# Altium schematic sheet 09_PMU.SchDoc(09_PMU)
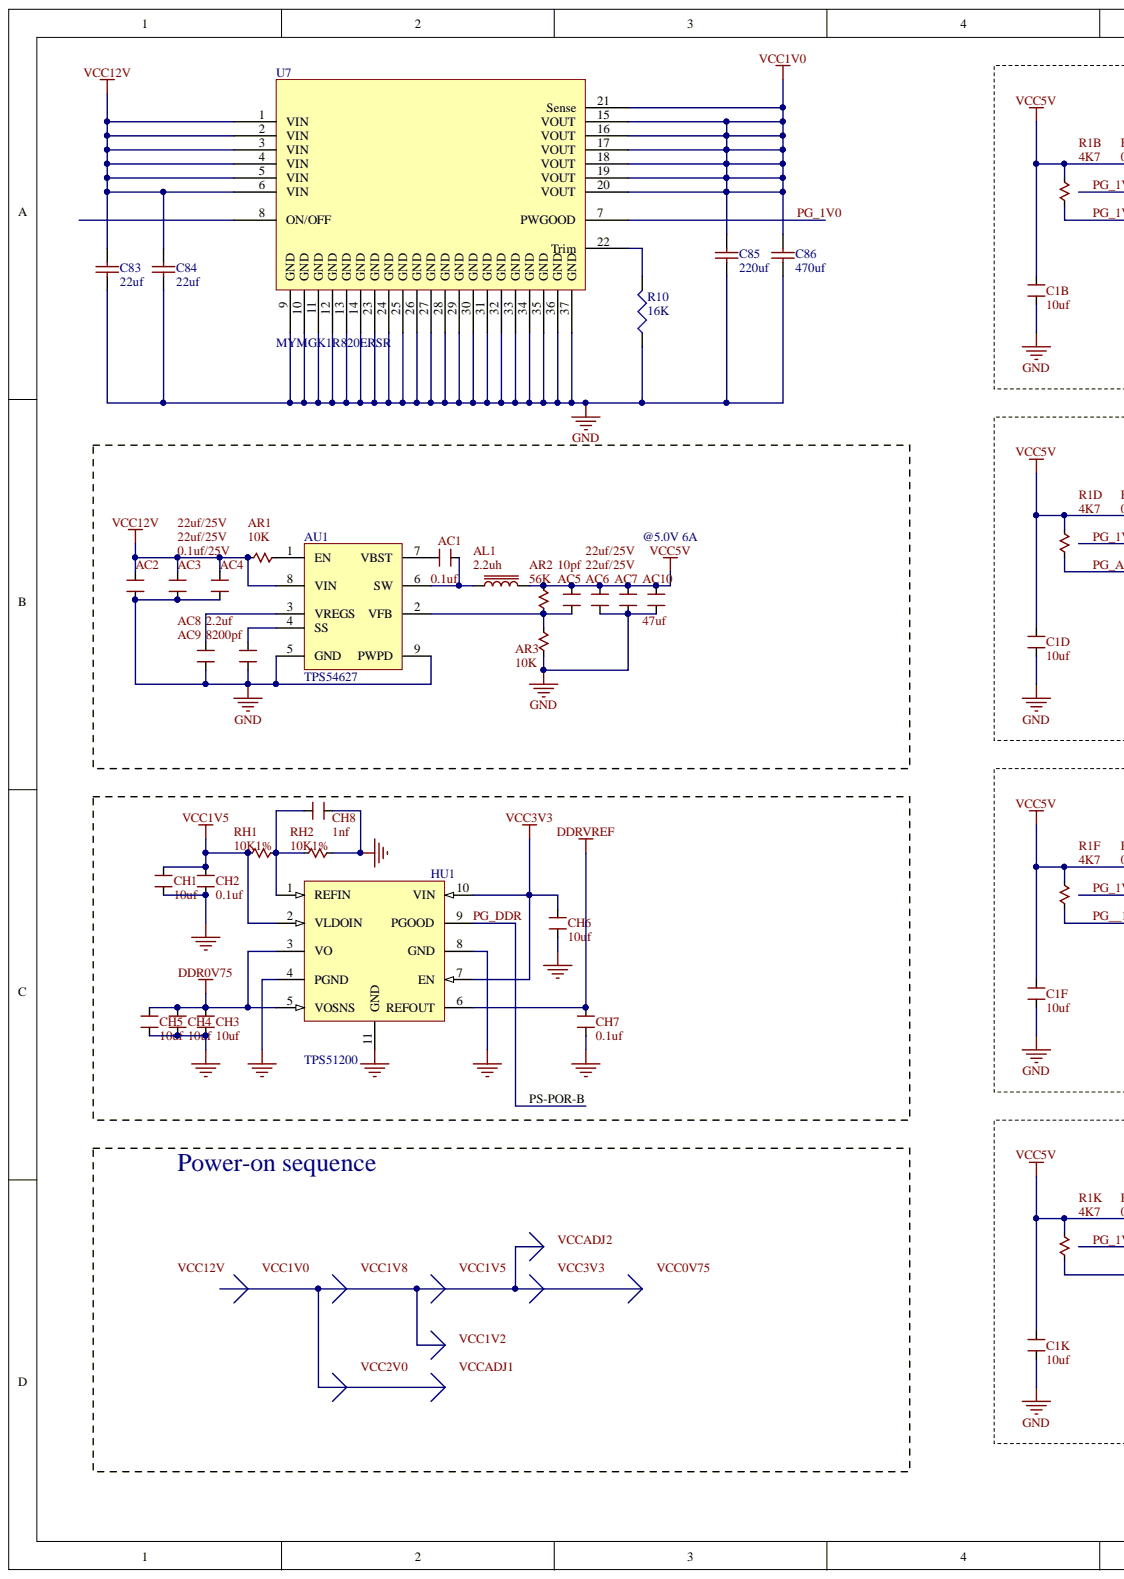
[diagram p0: 09_PMU.SchDoc, left]
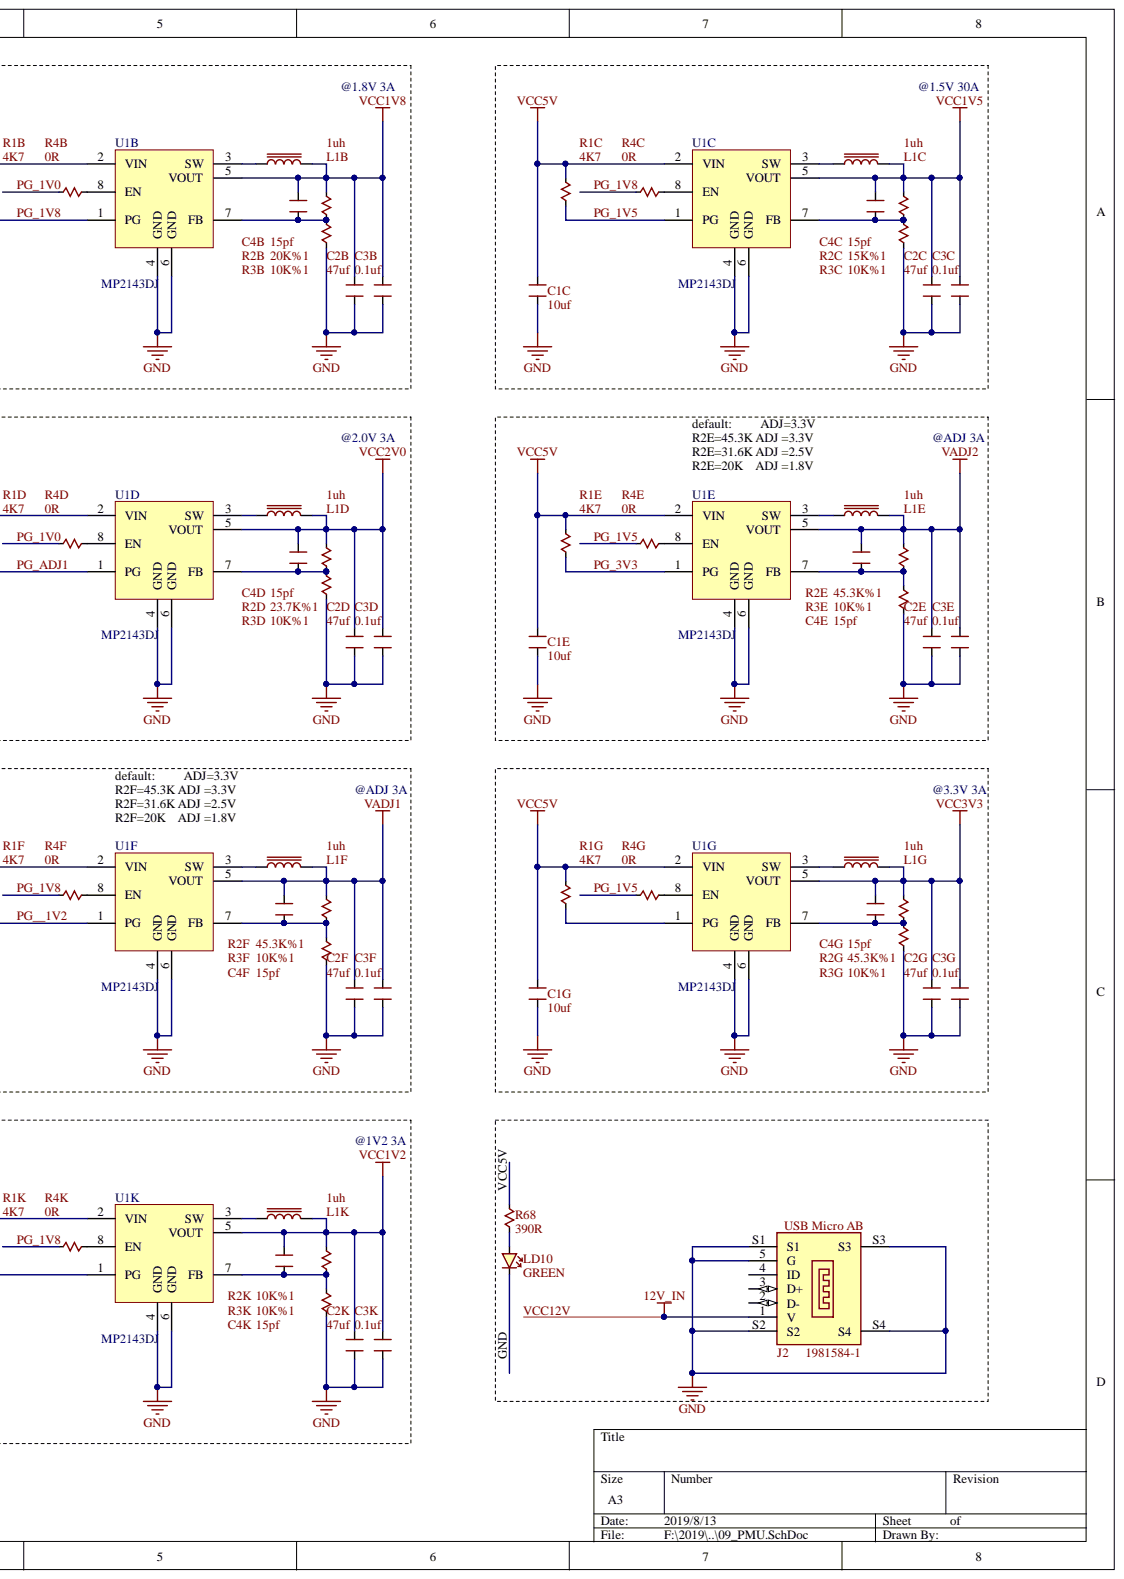
[diagram p1: 09_PMU.SchDoc, right]

## Parts
AC1  0.1uf  [CAP0402]
AC2  22uf/25V  [CAP0603]
AC3  22uf/25V  [CAP0603]
AC4  0.1uf/25V  [CAP0603]
AC5  10pf  [CAP0402]
AC6  22uf/25V  [CAP0603]
AC7  22uf/25V  [CAP0603]
AC8  2.2uf  [CAP0402]
AC9  8200pf  [CAP0402]
AC10  47uf  [C1206]
AL1  2.2uh  [L4X4X2]
AR1  10K  [RES0402]
AR2  56K  [RES0402]
AR3  10K  [RES0402]
AU1  TPS54627  [TSOP8]
C1B  10uf  [CAP0402]
C1C  10uf  [CAP0402]
C1D  10uf  [CAP0402]
C1E  10uf  [CAP0402]
C1F  10uf  [CAP0402]
C1G  10uf  [CAP0402]
C1K  10uf  [CAP0402]
C2B  47uf  [CAP0603]
C2C  47uf  [CAP0603]
C2D  47uf  [CAP0603]
C2E  47uf  [CAP0603]
C2F  47uf  [CAP0603]
C2G  47uf  [CAP0603]
C2K  47uf  [CAP0603]
C3B  0.1uf  [CAP0402]
C3C  0.1uf  [CAP0402]
C3D  0.1uf  [CAP0402]
C3E  0.1uf  [CAP0402]
C3F  0.1uf  [CAP0402]
C3G  0.1uf  [CAP0402]
C3K  0.1uf  [CAP0402]
C4B  15pf  [CAP0402]
C4C  15pf  [CAP0402]
C4D  15pf  [CAP0402]
C4E  15pf  [CAP0402]
C4F  15pf  [CAP0402]
C4G  15pf  [CAP0402]
C4K  15pf  [CAP0402]
C83  22uf  [c1206]
C84  22uf  [c1206]
C85  220uf  [C1206]
C86  470uf  [CAP-D]
CH1  10uf  [CAP0402]
CH2  0.1uf  [CAP0402]
CH3  10uf  [CAP0402]
CH4  10uf  [CAP0402]
CH5  10uf  [CAP0402]
CH6  10uf  [CAP0402]
CH7  0.1uf  [CAP0402]
CH8  1nf  [CAP0402]
HU1  TPS51200  [VQFN10]
J2  1981584-1  [MicroUsb]
L1B  1uh  [IHLP-2020BZ-01]
L1C  1uh  [IHLP-2020BZ-01]
L1D  1uh  [IHLP-2020BZ-01]
L1E  1uh  [IHLP-2020BZ-01]
L1F  1uh  [IHLP-2020BZ-01]
L1G  1uh  [IHLP-2020BZ-01]
L1K  1uh  [IHLP-2020BZ-01]
LD10  value GREEN  [D0603]  (hidden comment: LED)
R1B  4K7  [RES0402]
R1C  4K7  [RES0402]
R1D  4K7  [RES0402]
R1E  4K7  [RES0402]
R1F  4K7  [RES0402]
R1G  4K7  [RES0402]
R1K  4K7  [RES0402]
R2B  20K%1  [RES0402]
R2C  15K%1  [RES0402]
R2D  23.7K%1  [RES0402]
R2E  45.3K%1  [RES0402]
R2F  45.3K%1  [res0402]
R2G  45.3K%1  [RES0402]
R2K  10K%1  [RES0402]
R3B  10K%1  [RES0402]
R3C  10K%1  [RES0402]
R3D  10K%1  [RES0402]
R3E  10K%1  [RES0402]
R3F  10K%1  [RES0402]
R3G  10K%1  [RES0402]
R3K  10K%1  [RES0402]
R4B  0R  [RES0402]
R4C  0R  [RES0402]
R4D  0R  [RES0402]
R4E  0R  [RES0402]
R4F  0R  [RES0402]
R4G  0R  [RES0402]
R4K  0R  [RES0402]
R10  16K  [J1-0603]
R68  390R  [RES0402]
RH1  10K1%  [RES0402]
RH2  10K1%  [RES0402]
U1B  MP2143DJ  [SOT23-8]
U1C  MP2143DJ  [SOT23-8]
U1D  MP2143DJ  [SOT23-8]
U1E  MP2143DJ  [SOT23-8]
U1F  MP2143DJ  [SOT23-8]
U1G  MP2143DJ  [SOT23-8]
U1K  MP2143DJ  [SOT23-8]
U7  MYMGK1R820ERSR  [MYMGK1R820ERSR]

## Nets
DDR0V75: CH3.2 CH4.2 CH5.2 HU1.3(VO) HU1.5(VOSNS)
DDRVREF: CH7.2 HU1.6(REFOUT)
VADJ1: C2F.1 C3F.1 C4F.1 L1F.2 R2F.1 U1F.5(VOUT)
VADJ2: C2E.1 C3E.1 C4E.1 L1E.2 R2E.1 U1E.5(VOUT)
GND: AC2.1 AC3.1 AC4.1 AC6.1 AC7.1 AC8.1 AC9.1 AC10.1 AR3.2 AU1.5(GND) AU1.9(PWPD) C1B.2 C1C.2 C1D.2 C1E.2 C1F.2 C1G.2 C1K.2 C2B.2 C2C.2 C2D.2 C2E.2 C2F.2 C2G.2 C2K.2 C3B.2 C3C.2 C3D.2 C3E.2 C3F.2 C3G.2 C3K.2 C83.1 C84.1 C85.1 C86.1 CH1.1 CH2.1 CH3.1 CH4.1 CH5.1 CH6.1 CH7.1 CH8.1 HU1.4(PGND) HU1.8(GND) HU1.11(GND) J2.5(G) J2.S1 J2.S2 J2.S3 J2.S4 LD10.K R3B.2 R3C.2 R3D.2 R3E.2 R3F.2 R3G.2 R3K.2 R10.1 RH2.2 U1B.4(GND) U1B.6(GND) U1C.4(GND) U1C.6(GND) U1D.4(GND) U1D.6(GND) U1E.4(GND) U1E.6(GND) U1F.4(GND) U1F.6(GND) U1G.4(GND) U1G.6(GND) U1K.4(GND) U1K.6(GND) U7.9(GND) U7.10(GND) U7.11(GND) U7.12(GND) U7.13(GND) U7.14(GND) U7.23(GND) U7.24(GND) U7.25(GND) U7.26(GND) U7.27(GND) U7.28(GND) U7.29(GND) U7.30(GND) U7.31(GND) U7.32(GND) U7.33(GND) U7.34(GND) U7.35(GND) U7.36(GND) U7.37(GND)
PG_1V0: R4B.1 R4D.1 U7.7(PWGOOD)
PG_1V5: R1C.2 R4E.1 R4G.1 U1C.1(PG)
PG_1V8: R1B.2 R4C.1 R4F.1 R4K.1 U1B.1(PG)
PG_3V3: R1E.2 U1E.1(PG)
PG__1V2: R1F.2 U1F.1(PG)
PG_ADJ1: R1D.2 U1D.1(PG)
PG_DDR: HU1.9(PGOOD)
VCC1V0: C85.2 C86.2 U7.15(VOUT) U7.16(VOUT) U7.17(VOUT) U7.18(VOUT) U7.19(VOUT) U7.20(VOUT) U7.21(Sense)
VCC1V2: C2K.1 C3K.1 C4K.1 L1K.2 R2K.1 U1K.5(VOUT)
VCC1V5: C2C.1 C3C.1 C4C.1 CH1.2 CH2.2 HU1.2(VLDOIN) L1C.2 R2C.1 RH1.1 U1C.5(VOUT)
VCC1V8: C2B.1 C3B.1 C4B.1 L1B.2 R2B.1 U1B.5(VOUT)
VCC2V0: C2D.1 C3D.1 C4D.1 L1D.2 R2D.1 U1D.5(VOUT)
VCC3V3: C2G.1 C3G.1 C4G.1 CH6.2 HU1.7(EN) HU1.10(VIN) L1G.2 R2G.1 U1G.5(VOUT)
VCC5V: AC5.1 AC6.2 AC7.2 AC10.2 AL1.2 AR2.1 C1B.1 C1C.1 C1D.1 C1E.1 C1F.1 C1G.1 C1K.1 R1B.1 R1C.1 R1D.1 R1E.1 R1F.1 R1G.1 R1K.1 R68.1 U1B.2(VIN) U1C.2(VIN) U1D.2(VIN) U1E.2(VIN) U1F.2(VIN) U1G.2(VIN) U1K.2(VIN)
VCC12V: AC2.2 AC3.2 AC4.2 AR1.2 AU1.8(VIN) C83.2 C84.2 J2.1(V) U7.1(VIN) U7.2(VIN) U7.3(VIN) U7.4(VIN) U7.5(VIN) U7.6(VIN)
NetAC1_1: AC1.1 AL1.1 AU1.6(SW)
NetAC1_2: AC1.2 AU1.7(VBST)
NetAC5_2: AC5.2 AR2.2 AR3.1 AU1.2(VFB)
NetAC8_2: AC8.2 AU1.3(VREGS)
NetAC9_2: AC9.2 AU1.4(SS)
NetAR1_1: AR1.1 AU1.1(EN)
NetC4B_2: C4B.2 R2B.2 R3B.1 U1B.7(FB)
NetC4C_2: C4C.2 R2C.2 R3C.1 U1C.7(FB)
NetC4D_2: C4D.2 R2D.2 R3D.1 U1D.7(FB)
NetC4E_2: C4E.2 R2E.2 R3E.1 U1E.7(FB)
NetC4F_2: C4F.2 R2F.2 R3F.1 U1F.7(FB)
NetC4G_2: C4G.2 R2G.2 R3G.1 U1G.7(FB)
NetC4K_2: C4K.2 R2K.2 R3K.1 U1K.7(FB)
NetCH8_2: CH8.2 HU1.1(REFIN) RH1.2 RH2.1
NetL1B_1: L1B.1 U1B.3(SW)
NetL1C_1: L1C.1 U1C.3(SW)
NetL1D_1: L1D.1 U1D.3(SW)
NetL1E_1: L1E.1 U1E.3(SW)
NetL1F_1: L1F.1 U1F.3(SW)
NetL1G_1: L1G.1 U1G.3(SW)
NetL1K_1: L1K.1 U1K.3(SW)
NetLD10_A: LD10.A R68.2
NetR1G_2: R1G.2 U1G.1(PG)
NetR1K_2: R1K.2 U1K.1(PG)
NetR4B_2: R4B.2 U1B.8(EN)
NetR4C_2: R4C.2 U1C.8(EN)
NetR4D_2: R4D.2 U1D.8(EN)
NetR4E_2: R4E.2 U1E.8(EN)
NetR4F_2: R4F.2 U1F.8(EN)
NetR4G_2: R4G.2 U1G.8(EN)
NetR4K_2: R4K.2 U1K.8(EN)
NetR10_2: R10.2 U7.22(Trim)
N00653:
VCC0V75:
VCCADJ1:
VCCADJ2:

Not connected: J2 2(D-) 3(D+) 4(ID); U7 8(ON/OFF)

## Library data of the parts
AC1-AC10 = CAPACITOR: Generic Capacitor; Component Kind: Standard; Library Name: MZ7X100.IntLib
  AC1: Value: 0.1uf
  AC2 AC3 AC6 AC7: Value: 22uf/25V
  AC4: Value: 0.1uf/25V
  AC5: Value: 10pf
  AC8: Value: 2.2uf
  AC9: Value: 8200pf
  AC10: Value: 47uf
AL1 L1B L1C L1D L1E L1F L1G L1K = Inductor: Generic Inductor; Component Kind: Standard
  AL1: Library Name: MZ7X100.IntLib
  L1B L1C L1D L1E L1F L1G L1K: Library Name: Digilent.IntLib
AR1-AR3 = RESISTOR: Generic Resistor; Component Kind: Standard; Library Name: MZ7X100.IntLib
  AR1 AR3: Value: 10K
  AR2: Value: 56K
C1B C1C C1D C1E C1F C1G C1K C2B C2C C2D C2E C2F C2G C2K C3B C3C C3D C3E C3F C3G C3K C4B C4C C4D C4E C4F C4G C4K CH1-CH8 = CAPACITOR: Generic Capacitor; Value: 10nF; Component Kind: Standard
  C1B C1C C1D C1E C1F C1G C1K C2B C2C C2D C2E C2F C2G C2K C3B C3C C3D C3E C3F C3G C3K C4B C4C C4D C4E C4F C4G C4K: Library Name: MiZ7010N.IntLib
  CH1-CH8: Library Name: MIZ7035_定制.IntLib
C83 C84 = Cap4: Capacitor (Semiconductor SIM Model); Value: 0.01UF; Component Kind: Standard; LatestRevisionDate: 29-May-2009; LatestRevisionNote: IPC-7351 Footprint Added.; PackageDescription: Chip Capacitor; PackageReference: C1206; Published: 8-Jun-2000; Signal Integrity: Cap Semi; Simulation: CAP; Library Name: K7_676_定制.SCHLIB; Publisher: Altium Limited
C85 = Cap3: Capacitor (Semiconductor SIM Model); Value: 0.01UF; Component Kind: Standard; LatestRevisionDate: 29-May-2009; LatestRevisionNote: IPC-7351 Footprint Added.; PackageDescription: Chip Capacitor; PackageReference: C1206; Published: 8-Jun-2000; Signal Integrity: Cap Semi; Simulation: CAP; Library Name: K7_676_定制.SCHLIB; Publisher: Altium Limited
C86 = Cap5: Component Kind: Standard; Library Name: K7_676_定制.SCHLIB
J2 = TE 1981584: USB Micro AB connector; Manufacturer: Tyco Electronics; Component Kind: Standard; Library Name: Digilent.IntLib
LD10 = LED: Generic LED; Component Kind: Standard; Library Name: Digilent.IntLib
R1B R1C R1D R1E R1F R1G R1K R2B R2C R2D R2E R2F R2G R2K R3B R3C R3D R3E R3F R3G R3K R4B R4C R4D R4E R4F R4G R4K = RESISTOR: Generic Resistor; Value: 100; Component Kind: Standard; Library Name: MiZ7010N.IntLib
R10 = Res3: Resistor; Component Kind: Standard; LatestRevisionDate: 29-May-2009; LatestRevisionNote: IPC-7351 Footprint Added.; Library Name: Miscellaneous Devices.IntLib; PackageDescription: Chip Resistor; PackageReference: J1-0603; Published: 8-Jun-2000; Signal Integrity: Res; Simulation: RESISTOR; Value: 16K; Publisher: Altium Limited
R68 = RESISTOR: Generic Resistor; Value: 390; Component Kind: Standard; Library Name: Digilent.IntLib
RH1 RH2 = RESISTOR: Generic Resistor; Value: 10K; Component Kind: Standard; Library Name: MIZ7035_定制.IntLib
AU1 = TPS54627: Component Kind: Standard; Library Name: MZ7X100.IntLib
HU1 = TPS51200: Component Kind: Standard; Description: TPS51200; Library Name: MIZ7035_定制.IntLib
U1B U1C U1D U1E U1F U1G U1K = MP2143DJ: Component Kind: Standard; Library Name: MiZ7010N.IntLib
U7 = MYMGK1R820ERSR: Component Kind: Standard; Library Name: K7_676_定制.SCHLIB
